# Altium schematic sheet Battery Level Indicator.SchDoc
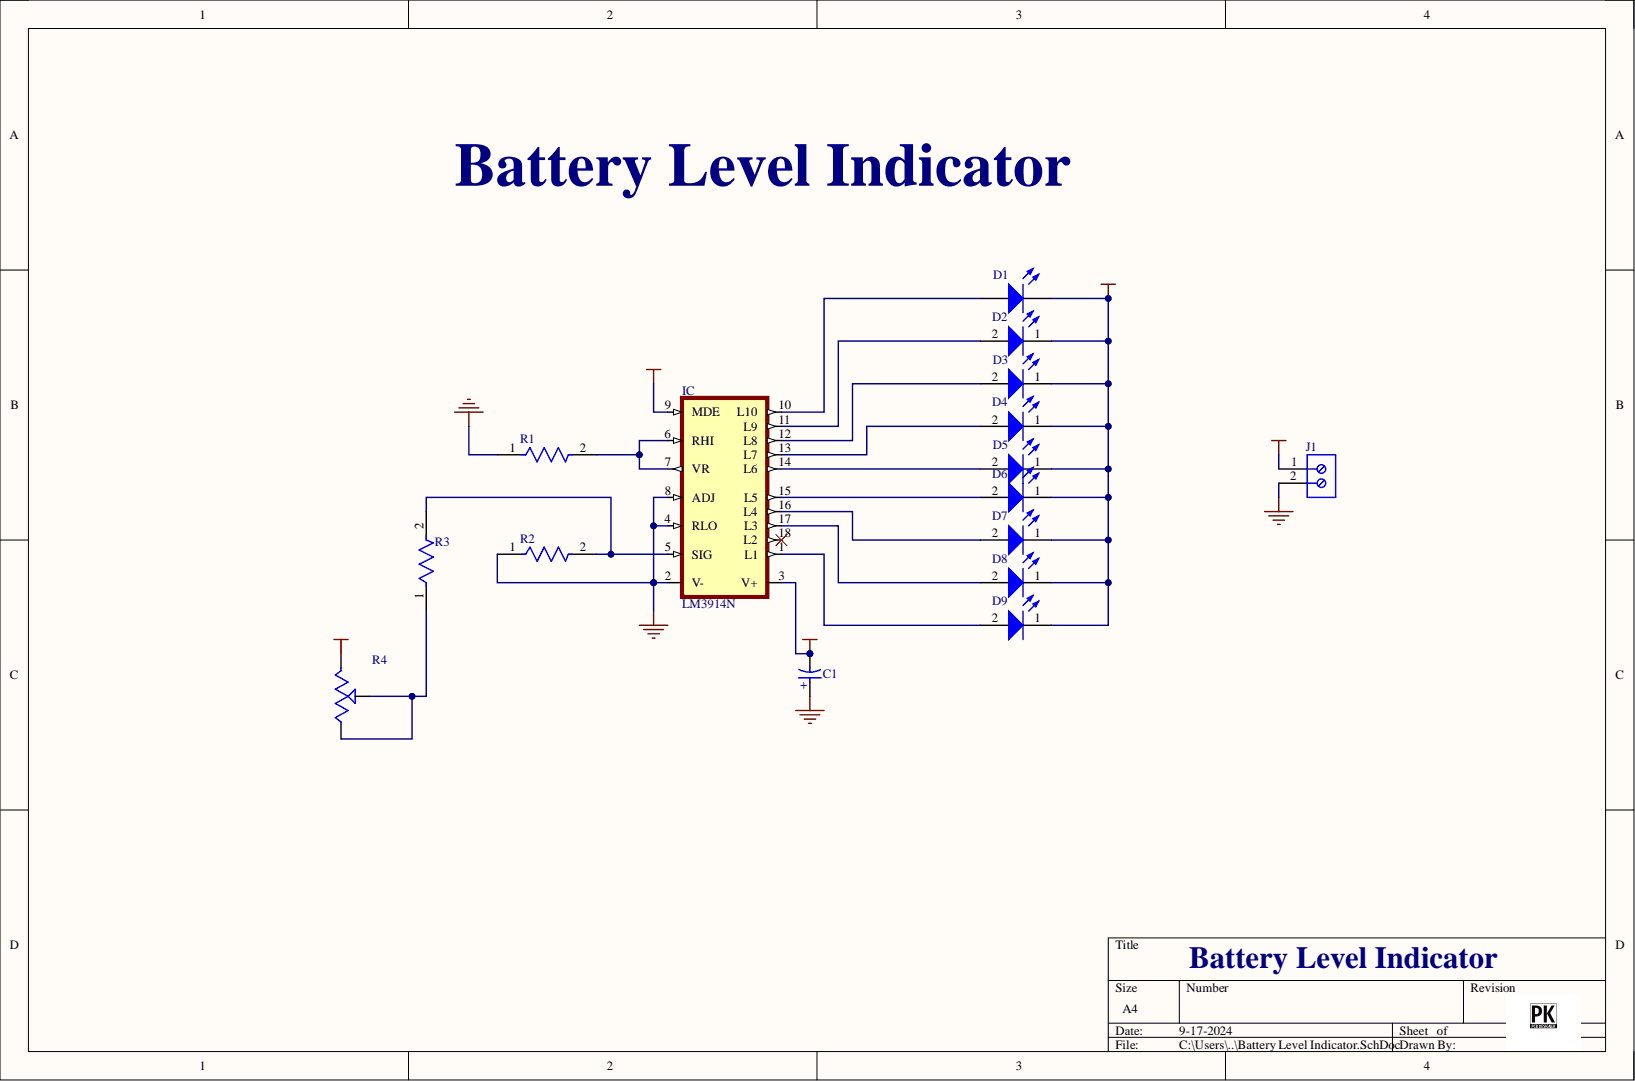
[diagram p0: Battery Level Indicator.SchDoc]

## Parts
C1  860130272001  [WCAP-ATET_D5H11]  (value not drawn)
D1  15400385A3590  [WL-TIRW_D3.9H5.3]  (value not drawn)
D2  15400385A3590  [WL-TIRW_D3.9H5.3]  (value not drawn)
D3  15400385A3590  [WL-TIRW_D3.9H5.3]  (value not drawn)
D4  15400385A3590  [WL-TIRW_D3.9H5.3]  (value not drawn)
D5  15400385A3590  [WL-TIRW_D3.9H5.3]  (value not drawn)
D6  15400385A3590  [WL-TIRW_D3.9H5.3]  (value not drawn)
D7  15400385A3590  [WL-TIRW_D3.9H5.3]  (value not drawn)
D8  15400385A3590  [WL-TIRW_D3.9H5.3]  (value not drawn)
D9  15400385A3590  [WL-TIRW_D3.9H5.3]  (value not drawn)
IC  LM3914N  [DIL18]
J1  691101710002  [691101710002]  (value not drawn)
R1  MRS25000C1000FRP00  [FP-MRS25-MFG]  (value not drawn)
R2  MRS25000C1000FRP00  [FP-MRS25-MFG]  (value not drawn)
R3  MRS25000C1000FRP00  [FP-MRS25-MFG]  (value not drawn)
R4  EVN-D8AA03B14  [TRIM_EVN-D8AA03B14]  (value not drawn)

## Nets
GND: C1.1 IC.2(V-) IC.4(RLO) IC.8(ADJ) J1.2 R1.1 R2.1
VCC: C1.2 D1.1 D2.1 D3.1 D4.1 D5.1 D6.1 D7.1 D8.1 D9.1 IC.3(V+) IC.9(MDE) J1.1 R4.3
NetD1_2: D1.2 IC.10(L10)
NetD2_2: D2.2 IC.11(L9)
NetD3_2: D3.2 IC.12(L8)
NetD4_2: D4.2 IC.13(L7)
NetD5_2: D5.2 IC.14(L6)
NetD6_2: D6.2 IC.15(L5)
NetD7_2: D7.2 IC.16(L4)
NetD8_2: D8.2 IC.17(L3)
NetD9_2: D9.2 IC.1(L1)
NetIC_5: IC.5(SIG) R2.2 R3.2
NetIC_6: IC.6(RHI) IC.7(VR) R1.2
NetR3_1: R3.1 R4.1 R4.2

Not connected: IC 18(L2)

## Library data of the parts
C1 = CMP-03913-54218058-1: 860130272001 WCAP-ATET Aluminum Electrolytic Capacitors; Capacitance: 47µF; Leakage Current: 4.7µA; Life (Hours): 1000hours; Material: Aluminium; Pitch: 2mm; Polarity: Polar; Ripple Current: 92mA; Tolerance: 20%; Voltage Rating: 10V; Case/Package: Radial; Component Kind: Standard; Diameter: 5mm; Height: 11mm; Height - Seated (Max): 12.4968mm; Lead Pitch: 2.0066mm; Length: 11mm; Library Name: Altium Content Vault; Max Operating Temperature: 125°C; Min Operating Temperature: -40°C; Mount: Through Hole; NexarPartId: 54218058; Packaging: Tape & Box (TB); RoHS: Compliant; Voltage Rating (DC): 10V
D1-D9 = CMP-03913-82270983-1: 15400385A3590 WL-TIRW THT Infrared Round Waterclear; Forward Current: 1A; Forward Voltage: 1.5V; Max Reverse Voltage (DC): 5V; Peak Wavelength: 850nm; Viewing Angle: 35°; Component Kind: Standard; Library Name: Altium Content Vault; NexarPartId: 82270983; Packaging: Bulk; RoHS: Non-Compliant; Wavelength: 850nm
IC = LM3914N: LED Lighting Drivers; MF: Texas Instruments; Availability: Unavailable; Component Kind: Standard; Library Name: LM3914N.SchLib; MP: LM3914N; Package: None; Price: None; SnapEDA_Link: https://www.snapeda.com/parts/LM3914N/Texas%20Instruments/view-part/4652973/?ref=snap
J1 = CMP-1502-03256-2: NexarPartId: 8917704; Current: 16A; Number of Contacts: 2; Number of Levels: 1; Pitch: 5.0038mm; Plating: Steel; Stripping Length: -7mm; Voltage: 250V; Wire Gauge (Max): 26AWG; Wire Gauge (Min): 14AWG; Color: Blue; Component Kind: Standard; Contact Material: Brass; Contact Plating: Tin; Current Rating: 16A; Height: 16.4mm; Housing Material: Nylon; Lead Pitch: 5mm; Length: 9mm; Library Name: Altium Content Vault; Max Operating Temperature: 105°C; Min Operating Temperature: -40°C; Mount: Through Hole; Number of Pins: 2; Number of Positions Per Level: 2; Packaging: Box; REACH SVHC: Unknown; RoHS: Compliant; Termination: Screw; Voltage Rating: 250V; Width: 11.2mm; Wire/Cable Gauge: 26AWG
R1-R3 = CMP-00030-42757237-1: MRS25000C1000FRP00 Resistor, 100 Ohm, ± 1%, 600 mW, Axial Leaded, Metal Film (Thin Film), Professional; Lead Diameter: 600µm; Max Power Dissipation: 600mW; Resistance: 100Ω; Temperature Coefficient: 50ppm/°C; Voltage Rating: 350V; Case/Package: Axial; Component Kind: Standard; Composition: Metal Film, Thin Film; Contact Plating: Tin; Diameter: 2.5mm; Lead Free: Lead Free; Length: 6.5mm; Library Name: Altium Content Vault; Max Operating Temperature: 155°C; Military Standard: Not; Min Operating Temperature: -55°C; Mount: Through Hole; NexarPartId: 42757237; Number of Pins: 2; Number of Terminations: 2; Packaging: Tape & Reel (TR); Power Rating: 600mW; Radiation Hardening: No; REACH SVHC: Unknown; RoHS: Compliant; Schedule B: 8533210090, 8533210090; Termination: Axial; Tolerance: 1%; Voltage Rating (DC): 350V; Width: 2.5mm
R4 = EVN-D8AA03B14: 10 kOhms 0.1W, 1/10W PC Pins Through Hole Trimmer Potentiometer Carbon 1.0 Turn Top Adjustment; MF: Panasonic Electronic; Availability: In Stock; Check_prices: https://www.snapeda.com/parts/EVN-D8AA03B14/Panasonic+Electronic+Components/view-part/?ref=eda; Component Kind: Standard; Library Name: EVN-D8AA03B14.SchLib; MP: EVN-D8AA03B14; Package: PQFP-44 Panasonic; Price: None; Purchase-URL: https://pricing.snapeda.com/search/part/EVN-D8AA03B14/?ref=eda; SnapEDA_Link: https://www.snapeda.com/parts/EVN-D8AA03B14/Panasonic+Electronic+Components/view-part/?ref=snap
